# Altium schematic sheet Power.SchDoc(Power)
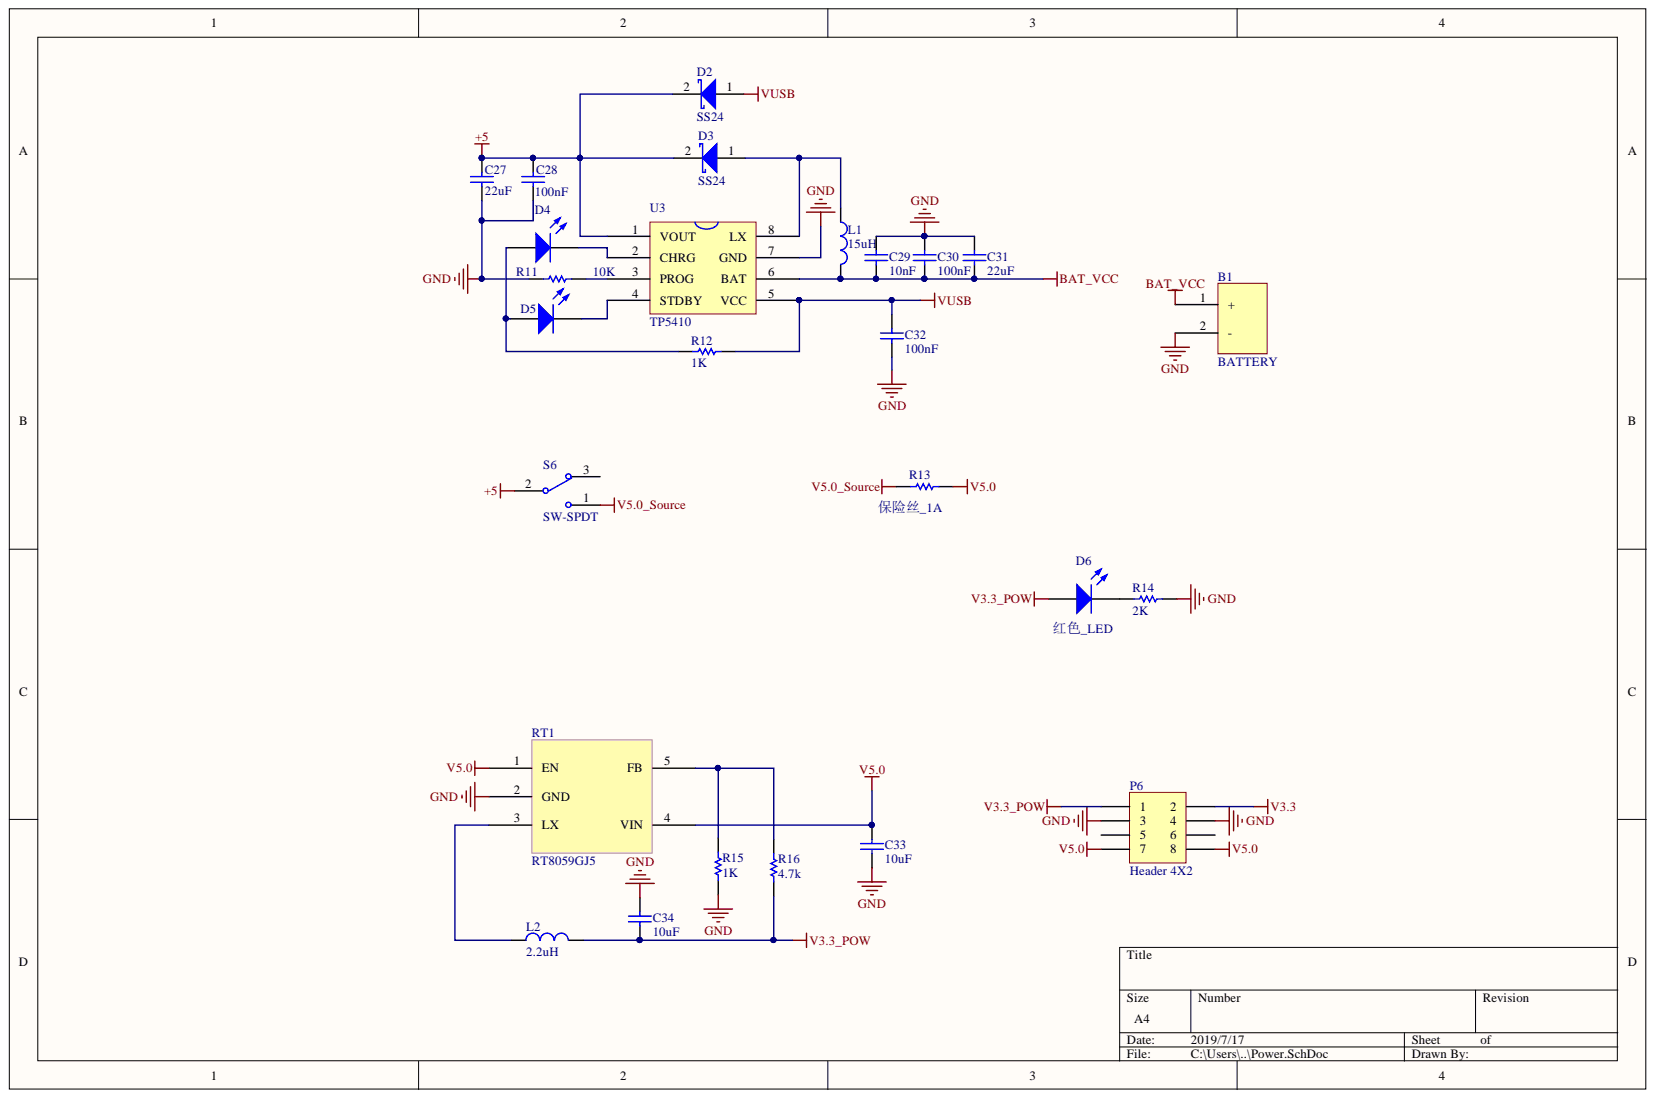
[diagram p0: Power.SchDoc]

## Parts
B1  BATTERY  [AAA]
C27  22uF  [LC-1206_C]
C28  100nF  [C0603]
C29  10nF  [C0603]
C30  100nF  [C0603]
C31  22uF  [LC-1206_C]
C32  100nF  [C0603]
C33  10uF  [LC-1206_C]
C34  10uF  [LC-1206_C]
D2  SS24  [SMA]
D3  SS24  [SMA]
D4  红色_LED  [LED_0805]  (value not drawn)
D5  黄绿_LED  [LED_0805]  (value not drawn)
D6  红色_LED  [LED_0805]
L1  15uH  [4x4]
L2  2.2uH  [NR2520]
P6  Header 4X2  [HDR4X2_A1]
R11  10K  [R0603]
R12  1K  [R0603]
R13  保险丝_1A  [R1206]
R14  2K  [R0603]
R15  1K  [R0603]
R16  4.7k  [R0603]
RT1  RT8059GJ5  [SOT23-5]
S6  SW-SPDT  [SWITCH_8.5]
U3  TP5410  [LC-SOIC-8_EP_150mil]

## Nets
+5: C27.2 C28.1 D2.2 D3.2 S6.2 U3.1(VOUT)
BAT_VCC: B1.1 C29.1 C30.1 C31.1 L1.2 U3.6(BAT)
GND: B1.2 C27.1 C28.2 C29.2 C30.2 C31.2 C32.1 C33.1 C34.2 P6.3 P6.4 R11.1 R14.2 R15.1 RT1.2(GND) U3.7(GND)
V3.3: P6.2
V3.3_POW: C34.1 D6.1 L2.2 P6.1 R16.2
V5.0: C33.2 P6.7 P6.8 R13.2 RT1.1(EN) RT1.4(VIN)
V5.0_Source: R13.1 S6.1
VUSB: C32.2 D2.1 R12.2 U3.5(VCC)
NetD3_1: D3.1 L1.1 U3.8(LX)
NetD4_1: D4.1 D5.1 R12.1
NetD4_2: D4.2 U3.2(CHRG)
NetD5_2: D5.2 U3.4(STDBY)
NetD6_2: D6.2 R14.1
NetL2_1: L2.1 RT1.3(LX)
NetR11_2: R11.2 U3.3(PROG)
NetR15_2: R15.2 R16.1 RT1.5(FB)

Not connected: P6 5 6; S6 3

## Library data of the parts
C27-C34 = CAP: Capacitor; Library Name: Devices.IntLib; Component Kind: Standard
D2 D3 = D Schottky: Schottky Diode; Simulation: SKYDIODE; Signal Integrity: D Schottky; Published: 8-Jun-2000; PackageReference: SMB; PackageDescription: DO-214-AA/SMB; 2 C-Bend Leads; Body 5.3 x 3.6 mm, inc. leads (LxW); Library Name: Devices.IntLib; LatestRevisionNote: Re-released for DXP Platform.; LatestRevisionDate: 17-Jul-2002; Component Kind: Standard; Code_JEDEC: DO-214-AA; Publisher: Altium Limited
D4-D6 = LED1: Typical RED GaAs LED; Simulation: LED1; Published: 8-Jun-2000; PackageReference: LED-1; PackageDescription: LED; 2 Leads; Library Name: Devices.IntLib; LatestRevisionNote: Mech Layer 1 removed.; LatestRevisionDate: 08-Jul-2005; Component Kind: Standard; Publisher: Altium Limited
L1 L2 = Inductor: Code_IEC: 1005; Simulation: INDUCTOR; Signal Integrity: Inductor; Published: 8-Jun-2000; PackageReference: 0402-A; PackageDescription: Chip Inductor; LatestRevisionNote: IPC-7351 Footprint Added.; LatestRevisionDate: 29-May-2009; Description: Inductor; Component Kind: Standard; Code_JEITA: 0402; Publisher: Altium Limited
  L1: Library Name: Devices.SchLib
  L2: Library Name: Devices.IntLib
P6 = Header 4X2: 接插件; Library Name: Connectors.IntLib; LatestRevisionNote: Re-released for DXP Platform.; LatestRevisionDate: 17-Jul-2002; Component Kind: Standard; Publisher: Altium Limited
R11 R13 R14 R16 = Res1: Resistor; Value: 1K; Simulation: RESISTOR; Signal Integrity: Res; Published: 8-Jun-2000; PackageReference: AXIAL-0.3; PackageDescription: Axial Device, Thru-Hole; 2 Leads; 0.3 in Pin Spacing; Library Name: Devices.IntLib; LatestRevisionNote: Re-released for DXP Platform.; LatestRevisionDate: 17-Jul-2002; Component Kind: Standard; Publisher: Altium Limited
R12 R15 = Res1: Resistor; Value: 1K; Simulation: RESISTOR; Signal Integrity: Res; Published: 8-Jun-2000; PackageReference: AXIAL-0.3; PackageDescription: Axial Device, Thru-Hole; 2 Leads; 0.3 in Pin Spacing; Library Name: Devices.IntLib; LatestRevisionNote: Re-released for DXP Platform.; LatestRevisionDate: 17-Jul-2002; Component Kind: Standard; Publisher: Altium Limited
S6 = SW-SPDT: SPDT Subminiature Toggle Switch, Right Angle Mounting, Vertical Actuation; Published: 24-Mar-1999; PackageReference: TL36WW15050; PackageDescription: Switch; 4 Leads; Library Name: Devices.IntLib; LatestRevisionNote: Schematic revised, PCB Footprint replaced.; LatestRevisionDate: 17-Dec-2003; DatasheetVersion: 05-Dec-2000; ComponentLink1URL: http://www.apem.com; ComponentLink1Description: Manufacturer Link; Component Kind: Standard; Publisher: Altium Limited
B1 = BATTERY: Library Name: Custom.IntLib; Component Kind: Standard
RT1 = RT8059GJ5: Library Name: IC.IntLib; ComponentLink2URL: http://www.szlcsc.com/product/pdf/A_4D6F2B8D304948682E0838EF1DB1F2AB.PDF; ComponentLink2Description: Datasheet; ComponentLink1URL: http://item.szlcsc.com/21253.html; ComponentLink1Description: Shop; Component Kind: Standard
U3 = TP5410: Library Name: IC.IntLib; Component Kind: Standard
